# Altium schematic sheet Misc.SCHDOC(Misc)
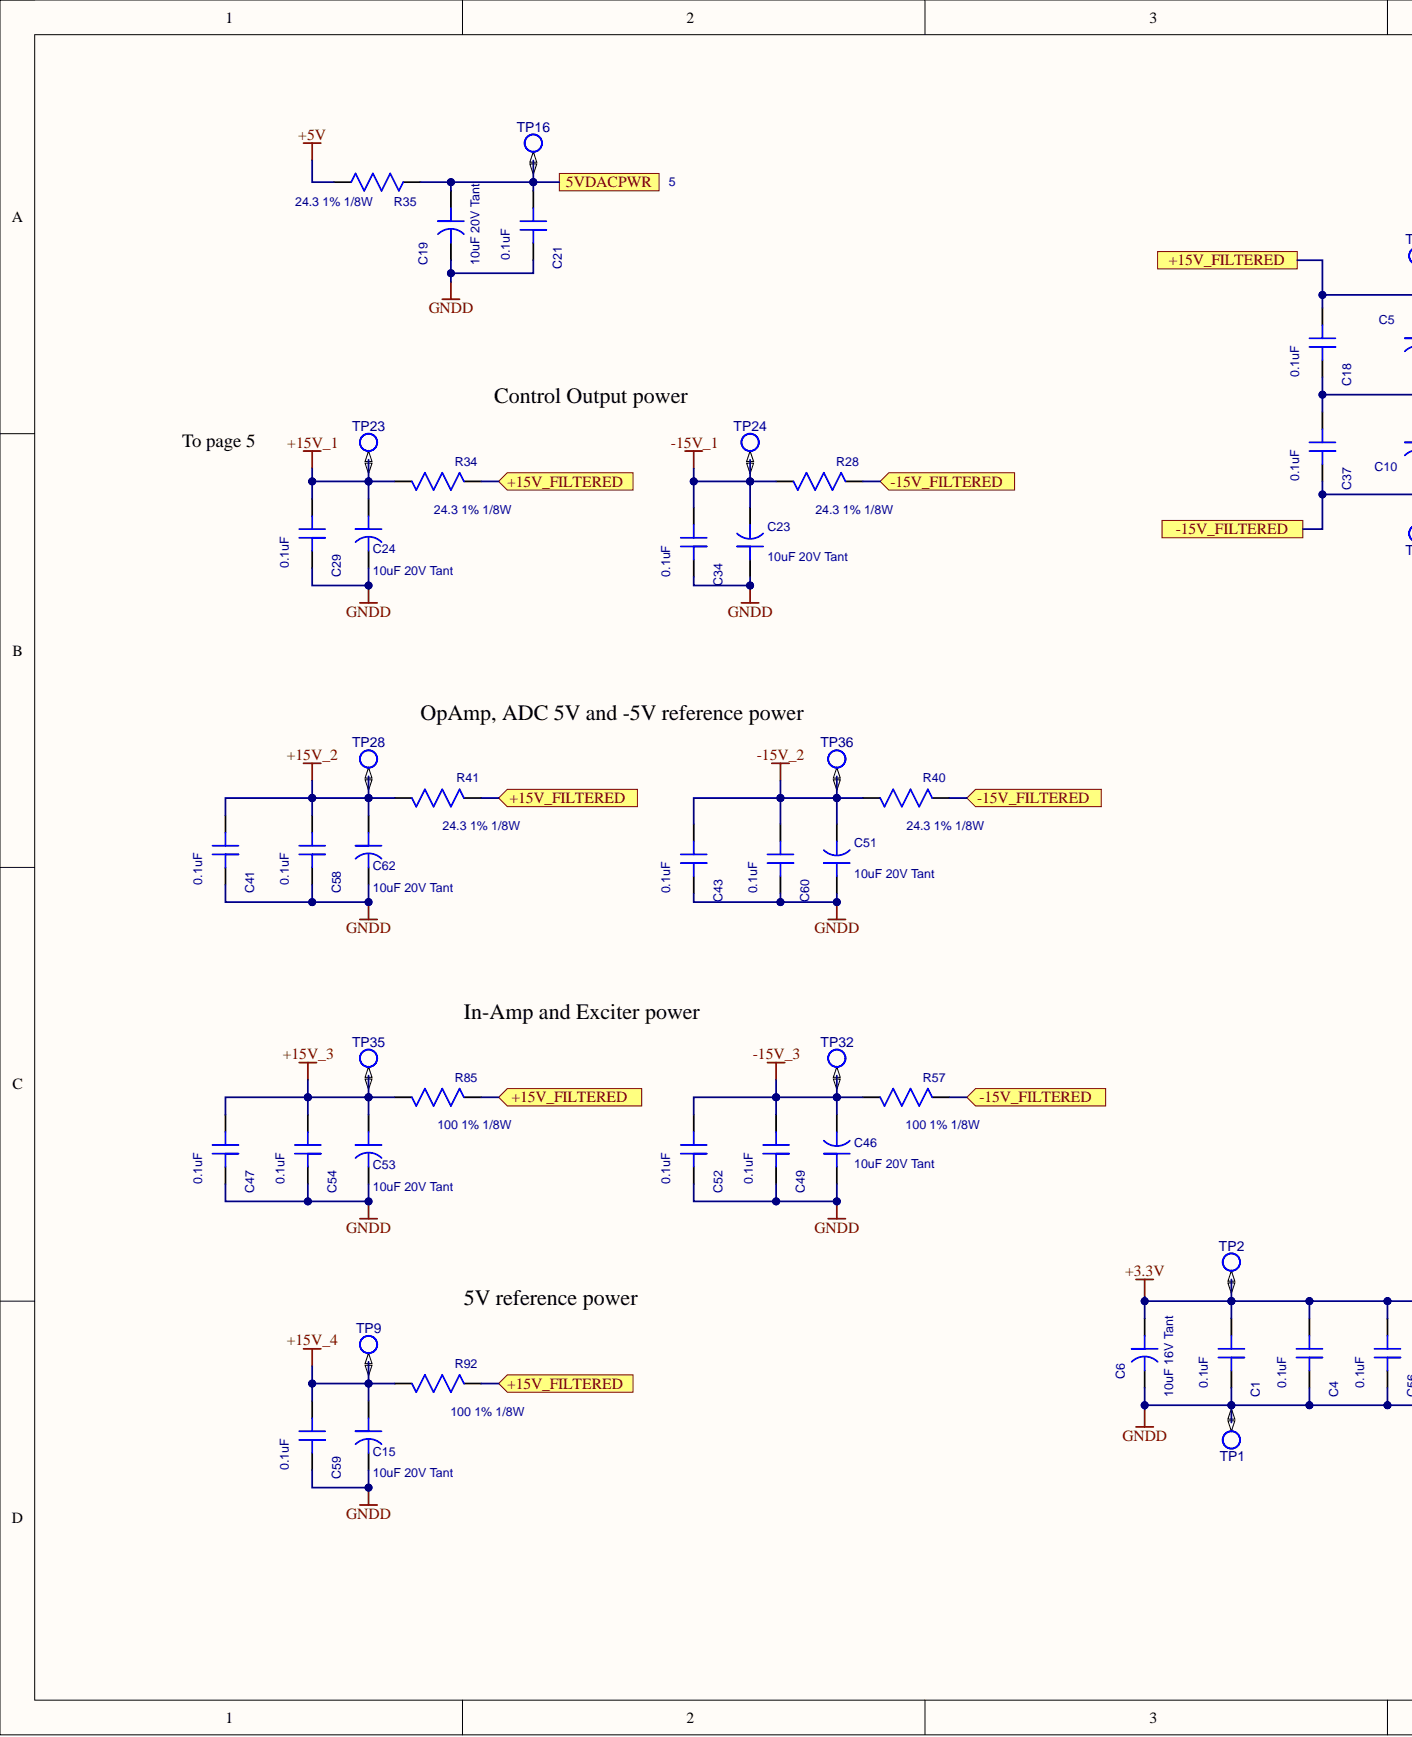
[diagram p0: Misc.SCHDOC, left]
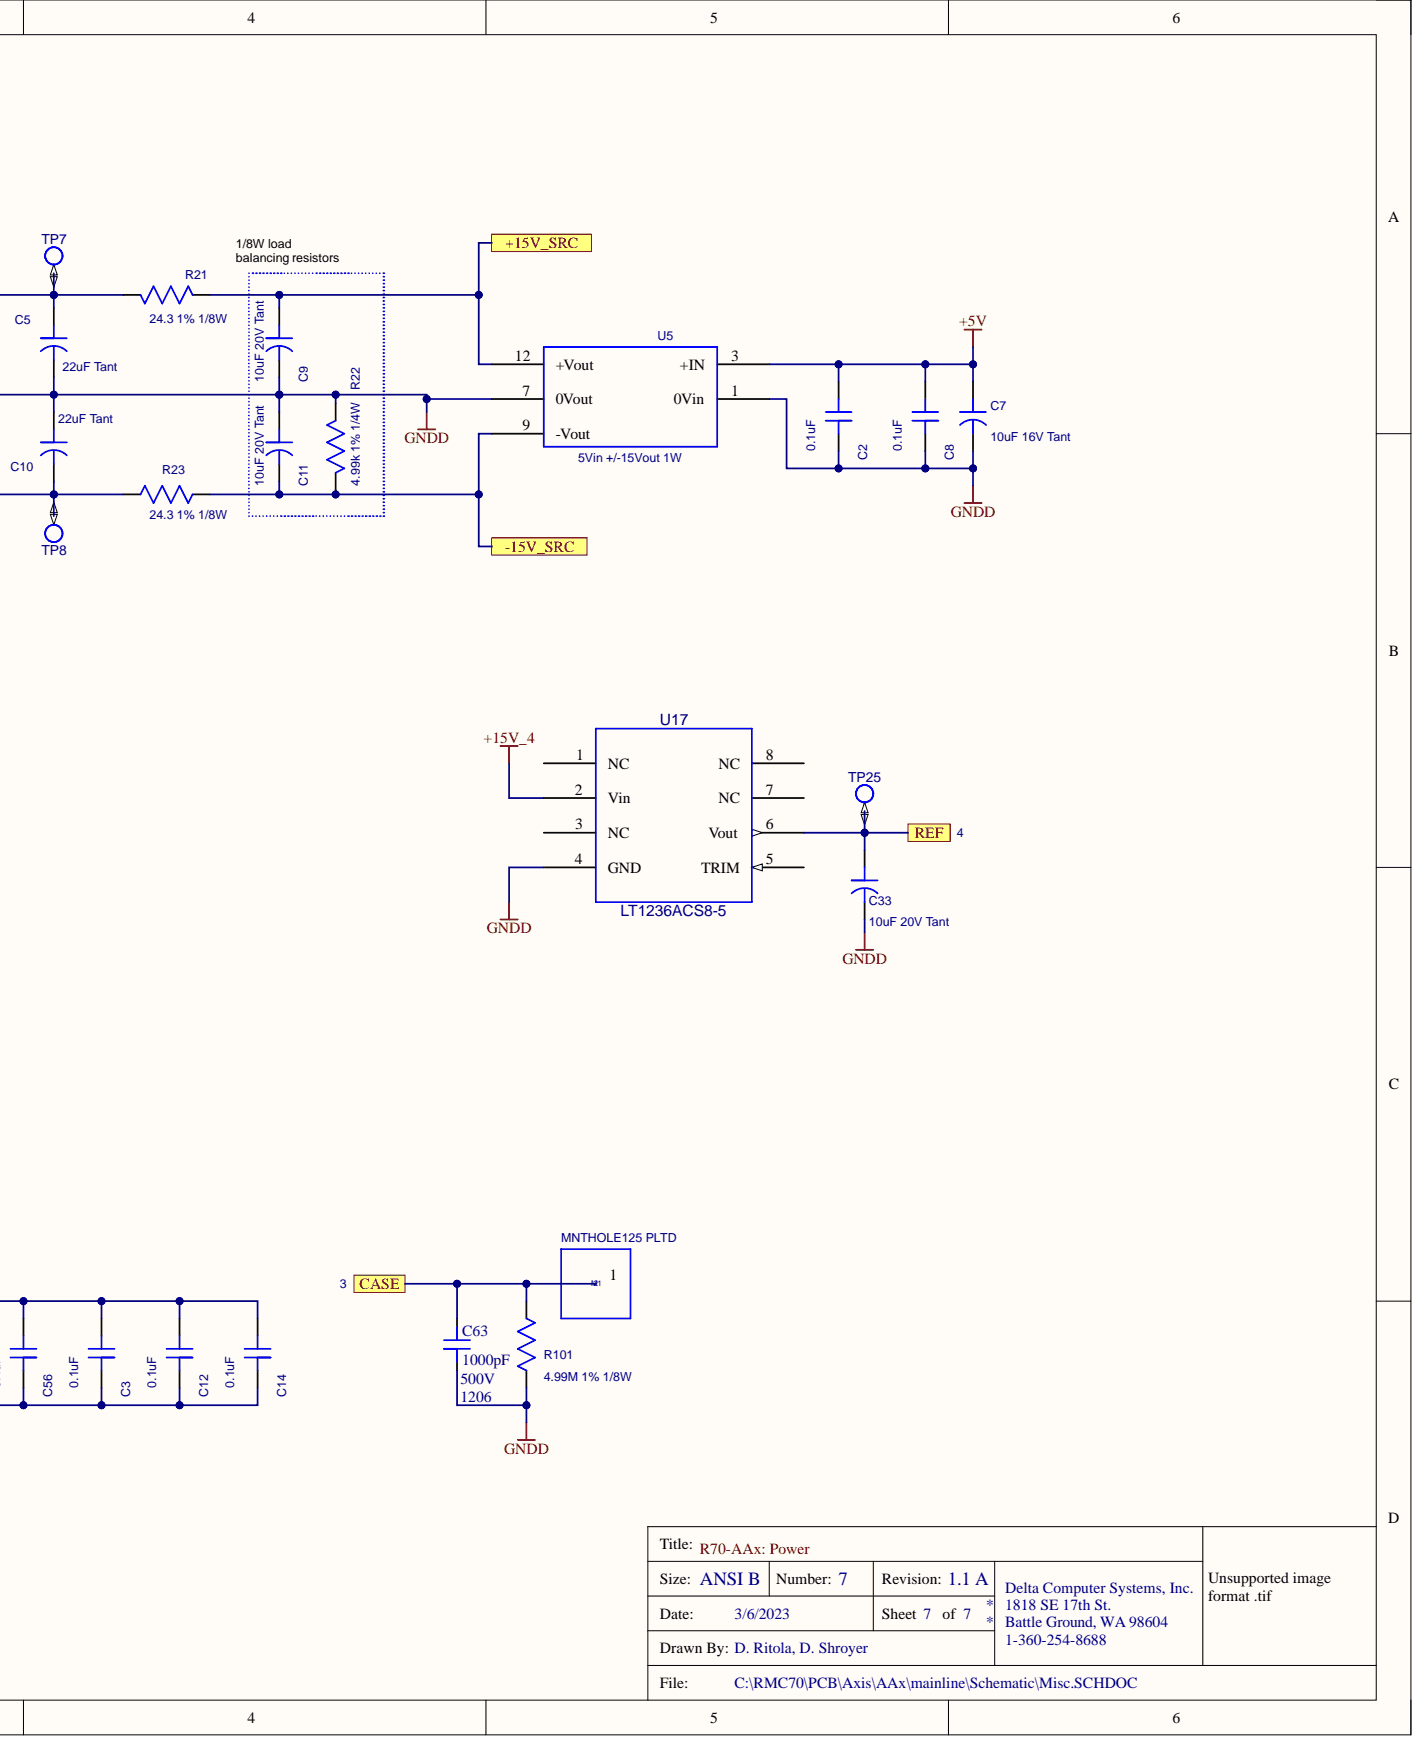
[diagram p1: Misc.SCHDOC, right]

## Parts
C1  0.1uF  [CC0603]
C2  0.1uF  [CC0603]
C3  0.1uF  [CC0603]
C4  0.1uF  [CC0603]
C5  22uF Tant  [CASE C POL]
C6  10uF 16V Tant  [CAP_SMT1210]
C7  10uF 16V Tant  [CAP_SMT1210]
C8  0.1uF  [CC0603]
C9  10uF 20V Tant  [CAP_SMT1210]
C10  22uF Tant  [CASE C POL]
C11  10uF 20V Tant  [CAP_SMT1210]
C12  0.1uF  [CC0603]
C14  0.1uF  [CC0603]
C15  10uF 20V Tant  [CAP_SMT1210]
C18  0.1uF  [CC0603]
C19  10uF 20V Tant  [CAP_SMT1210]
C21  0.1uF  [CC0603]
C23  10uF 20V Tant  [CAP_SMT1210]
C24  10uF 20V Tant  [CAP_SMT1210]
C29  0.1uF  [CC0603]
C33  10uF 20V Tant  [CAP_SMT1210]
C34  0.1uF  [CC0603]
C37  0.1uF  [CC0603]
C41  0.1uF  [CC0603]
C43  0.1uF  [CC0603]
C46  10uF 20V Tant  [CAP_SMT1210]
C47  0.1uF  [CC0603]
C49  0.1uF  [CC0603]
C51  10uF 20V Tant  [CAP_SMT1210]
C52  0.1uF  [CC0603]
C53  10uF 20V Tant  [CAP_SMT1210]
C54  0.1uF  [CC0603]
C56  0.1uF  [CC0603]
C58  0.1uF  [CC0603]
C59  0.1uF  [CC0603]
C60  0.1uF  [CC0603]
C62  10uF 20V Tant  [CAP_SMT1210]
C63  1000pF  CaseSize: 1206  RatedVoltage: 500V  [CAPC3216-N [1206]]
M1  MNTHOLE125 PLTD  [MNTHLE-PLTD]  (no BOM)
R21  24.3 1% 1/8W  [CC0805]
R22  4.99k 1% 1/4W  [1206]
R23  24.3 1% 1/8W  [CC0805]
R28  24.3 1% 1/8W  [CC0805]
R34  24.3 1% 1/8W  [CC0805]
R35  24.3 1% 1/8W  [CC0805]
R40  24.3 1% 1/8W  [CC0805]
R41  24.3 1% 1/8W  [CC0805]
R57  100 1% 1/8W  [CC0805]
R85  100 1% 1/8W  [CC0805]
R92  100 1% 1/8W  [CC0805]
R101  4.99M 1% 1/8W  [CC0805]
TP1  TP1  [TESTP_XY60D40]  (no BOM)
TP2  TP1  [TESTP_XY60D40]  (value not drawn)  (no BOM)
TP7  TP1  [TESTP_XY60D40]  (value not drawn)  (no BOM)
TP8  TP1  [TESTP_XY60D40]  (value not drawn)  (no BOM)
TP9  TP1  [TESTP_XY60D40]  (value not drawn)  (no BOM)
TP16  TP1  [TESTP_XY60D40]  (no BOM)
TP23  TP1  [TESTP_XY60D40]  (value not drawn)  (no BOM)
TP24  TP1  [TESTP_XY60D40]  (value not drawn)  (no BOM)
TP25  TP1  [TESTP_XY60D40]  (value not drawn)  (no BOM)
TP28  TP1  [TESTP_XY60D40]  (value not drawn)  (no BOM)
TP32  TP1  [TESTP_XY60D40]  (value not drawn)  (no BOM)
TP35  TP1  [TESTP_XY60D40]  (value not drawn)  (no BOM)
TP36  TP1  [TESTP_XY60D40]  (value not drawn)  (no BOM)
U5  5Vin +/-15Vout 1W  [DC-DC NTA_NTA0515M(Primary)]
U17  LT1236ACS8-5  [SO8]

## Ports
5VDACPWR, +15V_FILTERED, +15V_SRC, -15V_FILTERED, -15V_SRC, CASE, REF

## Nets
+3.3V: C1.1 C3.1 C4.1 C6.1 C12.1 C14.1 C56.1 TP2.1
+15V_1: C24.1 C29.1 R34.2 TP23.1
+15V_2: C41.1 C58.1 C62.1 R41.2 TP28.1
+15V_3: C47.1 C53.1 C54.1 R85.2 TP35.1
+15V_4: C15.1 C59.1 R92.2 TP9.1 U17.2(Vin)
-15V_1: C23.2 C34.1 R28.2 TP24.1
-15V_2: C43.1 C51.2 C60.1 R40.2 TP36.1
-15V_3: C46.2 C49.1 C52.1 R57.2 TP32.1
GNDD: C1.2 C2.2 C3.2 C4.2 C5.2 C6.2 C7.2 C8.2 C9.2 C10.1 C11.1 C12.2 C14.2 C15.2 C18.2 C19.2 C21.2 C23.1 C24.2 C29.2 C33.2 C34.2 C37.1 C41.2 C43.2 C46.1 C47.2 C49.2 C51.1 C52.2 C53.2 C54.2 C56.2 C58.2 C59.2 C60.2 C62.2 C63.2 R22.2 R101.1 TP1.1 U5.1(0Vin) U5.7(0Vout) U17.4(GND)
5VDACPWR: C2.1 C7.1 C8.1 C19.1 C21.1 R35.1 R35.2 TP16.1 U5.3(+IN) ; port 5VDACPWR
+15V_FILTERED: C5.1 C18.1 R21.2 R34.1 R41.1 R85.1 R92.1 TP7.1 ; port +15V_FILTERED
+15V_SRC: C9.1 R21.1 U5.12(+Vout) ; port +15V_SRC
-15V_FILTERED: C10.2 C37.2 R23.2 R28.1 R40.1 R57.1 TP8.1 ; port -15V_FILTERED
-15V_SRC: C11.2 R22.1 R23.1 U5.9(-Vout) ; port -15V_SRC
CASE: C63.1 M1.1 R101.2 ; port CASE
REF: C33.1 TP25.1 U17.6(Vout) ; port REF

Not connected: U17 1(NC) 3(NC) 5(TRIM) 7(NC) 8(NC)

## Library data of the parts
C1-C4 C8 C12 C14 C18 C21 C29 C34 C37 C41 C43 C47 C49 C52 C54 C56 C58-C60 = CAPNP_SMT0603: Cap Cer 0.1uF 50V 10% 0603 X7R; Manufacturer: Venkel; PartNumber: C0603X7R500-104KNE; Component Kind: Standard; Value: 0.1uF
C5 C10 = CAP POL CASE C: Cap Tant 22uF 20V 10% C; Manufacturer: AVX; PartNumber: TAJC226K020RNJ; Component Kind: Standard; Value: 22uF Tant
C6 C7 = CAP_SMT1210: Cap Tant 10uF 16V 10% B; Manufacturer: AVX; PartNumber: TAJB106K016RNJ; Component Kind: Standard; Value: 10uF 16V Tant
C9 C11 C15 C19 C24 C33 C53 C62 = CAP_SMT1210: Cap Tant 10uF 20V 10% B; Manufacturer: AVX; PartNumber: TAJB106K020RNJ; Component Kind: Standard; Value: 10uF 20V Tant
C23 C46 C51 = CAP_SMT1210_VARIANT_1: Cap Tant 10uF 20V 10% B; Manufacturer: AVX; PartNumber: TAJB106K020RNJ; Component Kind: Standard; Value: 10uF 20V Tant
C63 = Capacitor - Non-polarized: Cap Cer 1000pF 500V 10% 1206 X7R; Manufacturer: Venkel; ManufacturerAlt1: Various; PartNumber: C1206X7R501-102KNE; Notes: ECA0033; Type: Ceramic X7R; Component Kind: Standard; ComponentType: Capacitor; DateUpdated: 02/01/2023; Library Name: Capacitor.IntLib; PartNumberAlt1: Various; Tolerance: 10%; Value: 1000pF
R21 R23 R28 R34 R35 R40 R41 = RES_GEN_SMT0805: Res 24.3 1% 1/8W 0805; Manufacturer: Venkel; PartNumber: CR0805-8W-24R3FT; Component Kind: Standard; Value: 24.3 1% 1/8W
R22 = RES_GEN_1206: Res 4.99k 1% 1/4W 1206; Manufacturer: Venkel; PartNumber: CR1206-4W-4991FT; Component Kind: Standard; Value: 4.99k 1% 1/4W
R57 R85 R92 = RES_GEN_SMT0805: Res 100 1% 1/8W 0805; Manufacturer: Venkel; PartNumber: CR0805-8W-1000FT; Component Kind: Standard; Value: 100 1% 1/8W
R101 = RES_GEN_SMT0805: Res 4.99M 1% 1/8W 0805; Manufacturer: Venkel; PartNumber: CR0805-8W-4994FT; Component Kind: Standard; Value: 4.99M 1% 1/8W
TP1 TP2 TP7-TP9 TP16 TP23-TP25 TP28 TP32 TP35 TP36 = TP1: PDIF_I_PID: TP0; PDIF_TY: 255; Description: TP1
U5 = NTA0515M: CONV DC/DC 1W 05VIN +/-15VOUT; Manufacturer: Recom; PartNumber: R1D10-0515/P; Component Kind: Standard; Value: 5Vin +/-15Vout 1W
U17 = LT1236ACS8-5: Volt Ref Series 10mA 5V 8SOIC; Manufacturer: Linear Tech; PartNumber: LT1236ACS8-5#PBF; Component Kind: Standard
M1 = MNTHOLE125 PLTD: Description: MNTHOLE125 PLTD
